# Altium schematic sheet LM3409.2.SchDoc(LM3409.2)
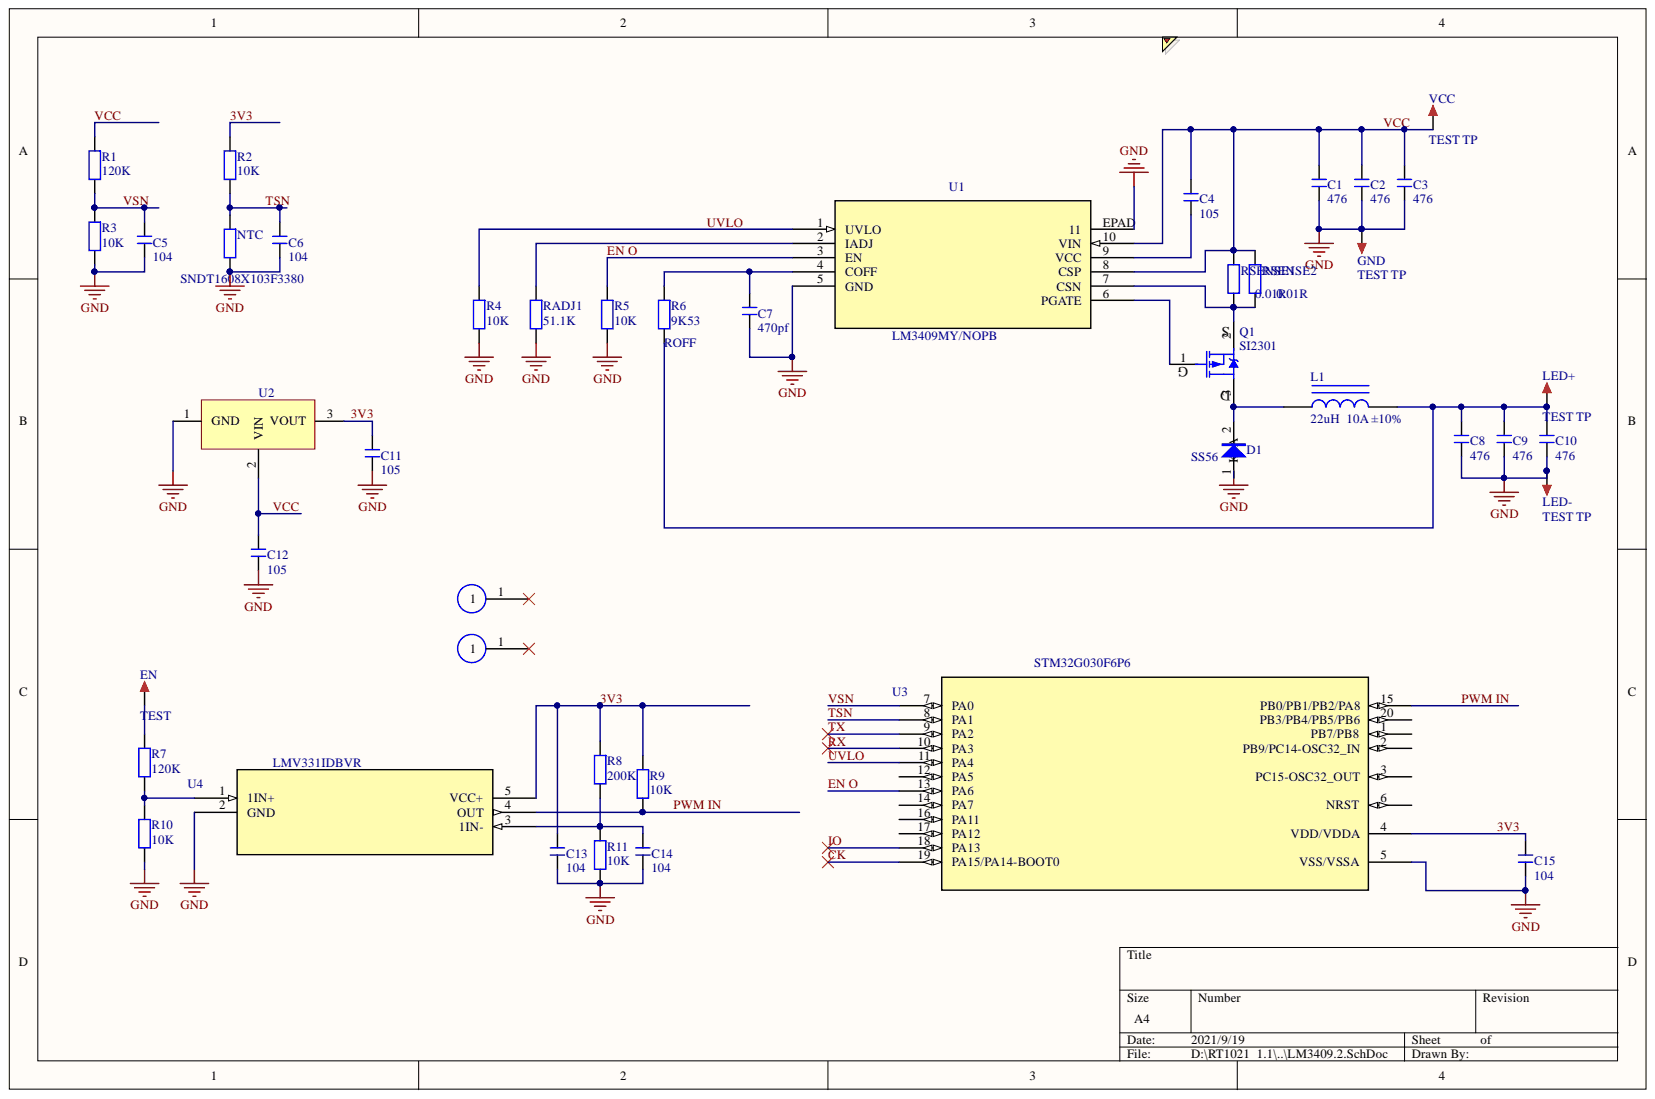
[diagram p0: LM3409.2.SchDoc]

## Parts
C1  476  [C 1206_L]
C2  476  [C 1206_L]
C3  476  [C 1206_L]
C4  105  [C 0603_L]
C5  104  [C 0603_L]
C6  104  [C 0603_L]
C7  470pf  [C 0603_L]
C8  476  [C 1206_L]
C9  476  [C 1206_L]
C10  476  [C 1206_L]
C11  105  [C 0603_L]
C12  105  [C 0603_L]
C13  104  [C 0603_L]
C14  104  [C 0603_L]
C15  104  [C 0603_L]
D1  SS56  [SMC]
EN  TEST  [hole]
GND  TEST TP  [hole]
H1  M3  [M3x10_L]  (value not drawn)
H2  M3  [M3x10_L]  (value not drawn)
L1  22uH  10A ±10%  [10A 22UH]
LED+  TEST TP  [hole]
LED-  TEST TP  [hole]
NTC  SNDT1608X103F3380  [R 0603_L]
Q1  SI2301  [TO252]
R1  120K  [R 0603_L]
R2  10K  [R 0603_L]
R3  10K  [R 0603_L]
R4  10K  [R 0603_L]
R5  10K  [R 0603_L]
R6  9K53  [R 0603_L]
R7  120K  [R 0603_L]
R8  200K  [R 0603_L]
R9  10K  [R 0603_L]
R10  10K  [R 0603_L]
R11  10K  [R 0603_L]
RADJ1  51.1K  [R 0603_L]
RSENSE1  0.01R  [R 1206_L]
RSENSE2  0.01R  [R 1206_L]
U1  LM3409MY/NOPB  [MUC10A-M]
U2  SGM2203 L-TYPE  [SOT89_M]  (value not drawn)
U3  STM32G030F6P6  [TSSOP20]
U4  LMV331IDBVR  [DBV5]
VCC  TEST TP  [hole]

## Nets
GND: C1.1 C2.1 C3.1 C5.1 C6.1 C7.1 C8.1 C9.1 C10.1 C11.1 C12.1 C13.1 C14.1 C15.1 D1.1 GND.1 LED-.1 NTC.1 R3.1 R4.1 R5.1 R10.1 R11.1 RADJ1.1 U1.5(GND) U1.EPAD U2.1 U3.5(VSS/VSSA) U4.2(GND)
3V3: C11.2 C13.2 C15.2 R2.2 R8.2 R9.2 U2.3 U3.4(VDD/VDDA) U4.5(VCC+)
CK: U3.19(PA15/PA14-BOOT0)
EN O: R5.2 U1.3(EN) U3.13(PA6)
IO: U3.18(PA13)
PWM IN: R9.1 U3.15(PB0/PB1/PB2/PA8) U4.4(OUT)
RX: U3.10(PA3)
TSN: C6.2 NTC.2 R2.1 U3.8(PA1)
TX: U3.9(PA2)
UVLO: R4.2 U1.1(UVLO) U3.11(PA4)
VCC: C1.2 C2.2 C3.2 C4.2 C12.2 R1.2 RSENSE1.2 RSENSE2.2 U1.8(CSP) U1.10(VIN) U2.2 VCC.1
VSN: C5.2 R1.1 R3.2 U3.7(PA0)
NetC4_1: C4.1 U1.9(VCC)
NetC7_2: C7.2 R6.2 U1.4(COFF)
NetC8_2: C8.2 C9.2 C10.2 L1.2 LED+.1 R6.1
NetC14_2: C14.2 R8.1 R11.2 U4.3(1IN-)
NetD1_2: D1.2 L1.1 Q1.3
NetEN_1: EN.1 R7.2
NetQ1_1: Q1.1 U1.6(PGATE)
NetQ1_2: Q1.2 RSENSE1.1 RSENSE2.1 U1.7(CSN)
NetR7_1: R7.1 R10.2 U4.1(1IN+)
NetRADJ1_2: RADJ1.2 U1.2(IADJ)

Not connected: H1 1; H2 1; U3 1(PB7/PB8) 2(PB9/PC14-OSC32_IN) 3(PC15-OSC32_OUT) 6(NRST) 12(PA5) 14(PA7) 16(PA11) 17(PA12) 20(PB3/PB4/PB5/PB6)

## Library data of the parts
C1-C3 = C: 无极性贴片电容; value: 47uf 50v  10%; package: 1206 x5r; Library Name: 3.1   - 电阻-电容-电感.SchLib; Component Kind: Standard
C4 C11 C12 = C: 无极性贴片电容; value: 1uf 50v 10%; package: 0603 x5r; Library Name: 3.1   - 电阻-电容-电感.SchLib; Component Kind: Standard
C5 C6 C13-C15 = C: 无极性贴片电容; value: 100nf 50v 10%; package: 0603 x5r; Library Name: 3.1   - 电阻-电容-电感.SchLib; Component Kind: Standard
C7 = C: 无极性贴片电容; value: 470pf 50v 10%; package: 0603 x5r; Library Name: 3.1   - 电阻-电容-电感.SchLib; Component Kind: Standard
C8-C10 = C: 无极性贴片电容; value: 47uf 50v 10%; package: 1206 Y5V; Library Name: 3.1   - 电阻-电容-电感.SchLib; Component Kind: Standard
D1 = SS34: 肖特基二极管; 版权归属: 源创客; value: 40V/3A; 学习资源: www.ooocke.com; Library Name: 8.1   - 二极管-整流桥.SchLib; Component Kind: Standard; BOM名称: 肖特基二极管
EN = TEST: 测试点; 版权归属: 源创客; 学习资源: www.ooocke.com; Library Name: 15.1 - 未分类器件.SchLib; Component Kind: Standard; BOM名称: 测试点
GND LED+ LED- VCC = TEST TP: 测试环; 版权归属: 源创客; 学习资源: www.ooocke.com; Library Name: 15.1 - 未分类器件.SchLib; Component Kind: Standard; BOM名称: 测试环
H1 H2 = M3安装孔: Component Kind: Standard
L1 = 0805 10uH 10%: 贴片电感; SuppliersPartNumber: C1046; Supplier: LC; Package: 15.5*7.3MM 6.4mm脚距; Library Name: Miscellaneous Devices LC.IntLib; ComponentLink1URL: http://www.szlcsc.com/product/details_1398.html; ComponentLink1Description: 供应商链接; Component Kind: Standard
NTC = R: 贴片电阻; value: 10K 1% B:3380; package: 0603; Library Name: 3.1   - 电阻-电容-电感.SchLib; Component Kind: Standard
Q1 = SI2301: P沟道场效应管; 版权归属: 源创客; 学习资源: www.ooocke.com; Library Name: 6.1   - 场效应管-可控硅.SchLib; Component Kind: Standard; BOM名称: P沟道场效应管
R1 R7 = R: 贴片电阻; value: 120K 1%; package: 0603; Library Name: 3.1   - 电阻-电容-电感.SchLib; Component Kind: Standard
R2-R5 = R: 贴片电阻; value: 10K7 1%; package: 0603; Library Name: 3.1   - 电阻-电容-电感.SchLib; Component Kind: Standard
R6 = R: 贴片电阻; value: 9K53 1%; package: 0603 x7r; Library Name: 3.1   - 电阻-电容-电感.SchLib; Component Kind: Standard
R8 = R: 贴片电阻; value: 200K 1%; package: 0603; Library Name: 3.1   - 电阻-电容-电感.SchLib; Component Kind: Standard
R9-R11 = R: 贴片电阻; value: 10K 1%; package: 0603; Library Name: 3.1   - 电阻-电容-电感.SchLib; Component Kind: Standard
RADJ1 = R: 贴片电阻; value: 51.1K 1%; package: 0603; Library Name: 3.1   - 电阻-电容-电感.SchLib; Component Kind: Standard
RSENSE1 RSENSE2 = R: 贴片电阻; value: 0.05R 1%; package: 2512合金电阻 3W; Library Name: 3.1   - 电阻-电容-电感.SchLib; Component Kind: Standard
U1 = LM3409MY/NOPB: PFET Buck Controller for High Power LED Drives; Vendor: Texas Instruments; NSC_PackageReference: MUC10A; basePN: LM3409; Type: DEV; RefDes: RefDes; Manufacturer_Part_Number: LM3409MY/NOPB; Manufacturer: Texas Instruments; DatasheetURL: http://www.ti.com/lit/ds/symlink/lm3409.pdf; Component Kind: Standard; Copyright: Copyright (C) 2018 Accelerated Designs. All rights reserved
U2 = LM317: 线性稳压芯片; value: LDO 36V max; package: SOT-89 LTYP; Library Name: 1.3   - 电源类芯片.SchLib; Component Kind: Standard
U3 = STM32G030F6P6: No Description Available; Vendor: STMicroelectronics; SourceLibrary: Updates_to_database_2020-10-19; Built_By: EMA_UL_Team; Type: DEV; RefDes: RefDes; Manufacturer_Part_Number: STM32G030F6P6; Component Kind: Standard; Copyright: Copyright (C) 2018 Accelerated Designs. All rights reserved
U4 = LMV331IDBVR_1: Single General Purpose Low-Voltage Comparator; GENERIC_PART_NUMBER: LMV331; Vendor: Texas Instruments; PIN_COUNT: 5; PACKAGE_DESIGNATOR: DBV; INDUSTRY_STD_PKG_TYPE: SOT-23; Type: DEV; RefDes: RefDes; Manufacturer_Part_Number: LMV331IDBVR; Manufacturer: Texas Instruments; FAMILY_NAME: COMPARATOR; Datasheet_URL: http://www.ti.com/lit/gpn/LMV331; Component Kind: Standard; Copyright: Copyright (C) 2021 Accelerated Designs. All rights reserved
